# Altium schematic sheet CONNS.SchDoc
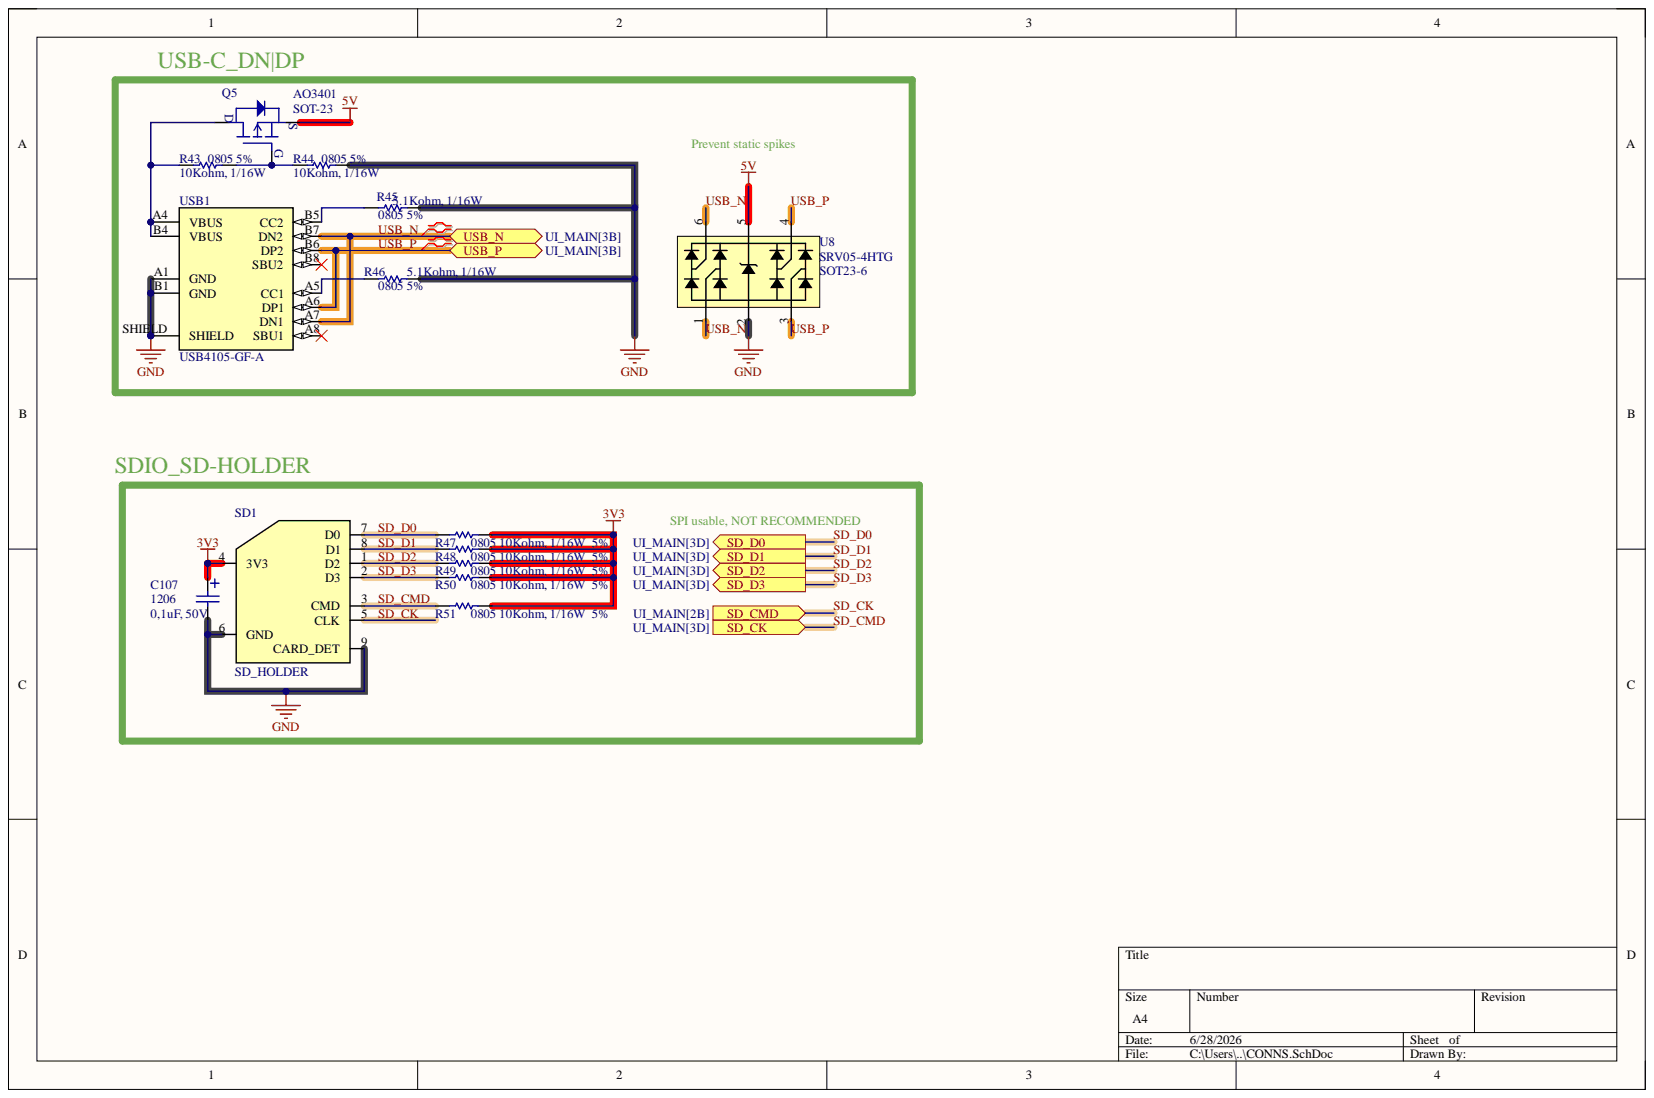
[diagram p0: CONNS.SchDoc]

## Parts
C107  0,1uF, 50V  Package: 1206  [C_1206+]
Q5  AO3401  Package: SOT-23  [PKG_SOT-23]
R43  10Kohm, 1/16W  Acc: 5%  Package: 0805  [R_0805]
R44  10Kohm, 1/16W  Acc: 5%  Package: 0805  [R_0805]
R45  5.1Kohm, 1/16W  Acc: 5%  Package: 0805  [R_0805]
R46  5.1Kohm, 1/16W  Acc: 5%  Package: 0805  [R_0805]
R47  10Kohm, 1/16W  Package: 0805  [R_0805]
R48  10Kohm, 1/16W  Package: 0805  [R_0805]
R49  10Kohm, 1/16W  Package: 0805  [R_0805]
R50  10Kohm, 1/16W  Package: 0805  [R_0805]
R51  10Kohm, 1/16W  Package: 0805  [R_0805]
SD1  SD_HOLDER  [CONN_SD_Holder]
U8  SRV05-4HTG  Pkg: SOT23-6  [PKG-SOT23-6]
USB1  USB4105-GF-A  [CONN_USB-C]

## Ports
SD_CK, SD_CMD, SD_D0, SD_D1, SD_D2, SD_D3, USB_N, USB_P

## Nets
3V3: C107.2 R47.2 R48.2 R49.2 R50.2 R51.2 SD1.4(3V3)
5V: Q5.2 U8.5
GND: C107.1 R44.2 R45.2 R46.2 SD1.6(GND) SD1.9(CARD_DET) U8.2 USB1.A1(GND) USB1.B1(GND) USB1.SHIELD
SD_CK: SD1.5(CLK) ; port SD_CMD
SD_CMD: R51.1 SD1.3(CMD) ; port SD_CK
SD_D0: R47.1 SD1.7(D0) ; port SD_D0
SD_D1: R48.1 SD1.8(D1) ; port SD_D1
SD_D2: R49.1 SD1.1(D2) ; port SD_D2
SD_D3: R50.1 SD1.2(D3) ; port SD_D3
USB_N: U8.1 U8.6 USB1.A7(DN1) USB1.B7(DN2) ; port USB_N
USB_P: U8.3 U8.4 USB1.A6(DP1) USB1.B6(DP2) ; port USB_P
NetQ5_1: Q5.1 R43.2 R44.1
NetQ5_3: Q5.3 R43.1 USB1.A4(VBUS) USB1.B4(VBUS)
NetR45_1: R45.1 USB1.B5(CC2)
NetR46_1: R46.1 USB1.A5(CC1)

Not connected: USB1 A8(SBU1) B8(SBU2)

## Library data of the parts
C107 = CAP+1206: Manufacturer 1: OEM; Manufacturer Part Number 1: N/a; Component Kind: Standard; Datasheet: N/a; Description: CAP+1206; Library Name: DisplayLib.IntLib; Supplier 1: Thegioiic; Supplier Link: N/a; Value: 0,1uF, 50V
Q5 = AO3401: P-Channel 30 V 4A (Ta) 1.4W (Ta) Surface Mount SOT-23-3; Manufacturer 1: Alpha&Omega Semi.; Component Kind: Standard; Datasheet: https://www.snapeda.com/parts/AO3401/Alpha%20&%20Omega%20Semiconductor/datasheet/; Library Name: DisplayLib.IntLib; Manufacturer Part Number 1: AO3401; Supplier 1: ICDayroi; Supplier Link: https://icdayroi.com/ao3401
R43-R46 = RES_0805: Manufacturer 1: Vishay; Manufacturer Part Number 1: RES0805; Component Kind: Standard; Datasheet: https://www.mouser.de/datasheet/2/447/PYu_RC_Group_51_RoHS_L_11-1984063.pdf; Description: RES_0805; Library Name: DisplayLib.IntLib; Supplier 1: Thegioiic; Supplier Link: N/a
  R43 R44: Value: 10Kohm, 1/16W
  R45 R46: Value: 5.1Kohm, 1/16W
R47-R51 = RES_0805: Manufacturer 1: Vishay; Manufacturer Part Number 1: RES0805; Acc: 5%; Component Kind: Standard; Datasheet: https://www.mouser.de/datasheet/2/447/PYu_RC_Group_51_RoHS_L_11-1984063.pdf; Description: RES_0805; Library Name: DisplayLib.IntLib; Supplier 1: Thegioiic; Supplier Link: N/a; Value: 10Kohm, 1/16W
SD1 = SD_HOLDER: MICRO SD MEMORY CARD SOCKET; Manufacturer 1: OEM; Manufacturer Part Number 1: MiSD-PP-9P-V1; Component Kind: Standard; Datasheet: N/a; Library Name: DisplayLib.IntLib; Package: MicroSD; Supplier 1: Thegioiic; Supplier Link: https://www.thegioiic.com/misd-pp-9p-v1-khay-the-nho-microsd-9-chan-push-push
U8 = SRV05-4HTG: Electrostatic Discharge Protection Device; Manufacturer 1: Littelfuse; Component Kind: Standard; Datasheet: https://www.littelfuse.com/assetdocs/littelfuse-tvs-diode-array-srv05-4htg-d-datasheet?assetguid=d716fc4c-0484-4b67-97d8-cf719554d89a; Library Name: DisplayLib.IntLib; Manufacturer Part Number 1: SRV05-4HTG; Supplier 1: digikey; Supplier Link: https://www.digikey.com/en/products/detail/littelfuse-inc/SRV05-4HTG/3130460
USB1 = USB_C: USB Type C Receptacle for USB2.0, SMT Type, PCB Top Mount; Manufacturer 1: GCT; Component Kind: Standard; Datasheet: https://gct.co/files/specs/usb4105-spec.pdf; Library Name: DisplayLib.IntLib; Manufacturer Part Number 1: USB4105-GF-A; Supplier 1: Thegioiic; Supplier Link: https://www.thegioiic.com/cong-usb-cai-3-1-type-c-16-chan-thang-dan-smd; Supplier Link 2: https://www.digikey.com/en/products/detail/gct/USB4105-GF-A/11198510
